# Altium schematic sheet S7CAS-PLEIADES-CARTE-MERE_P01_ALIM.SchDoc
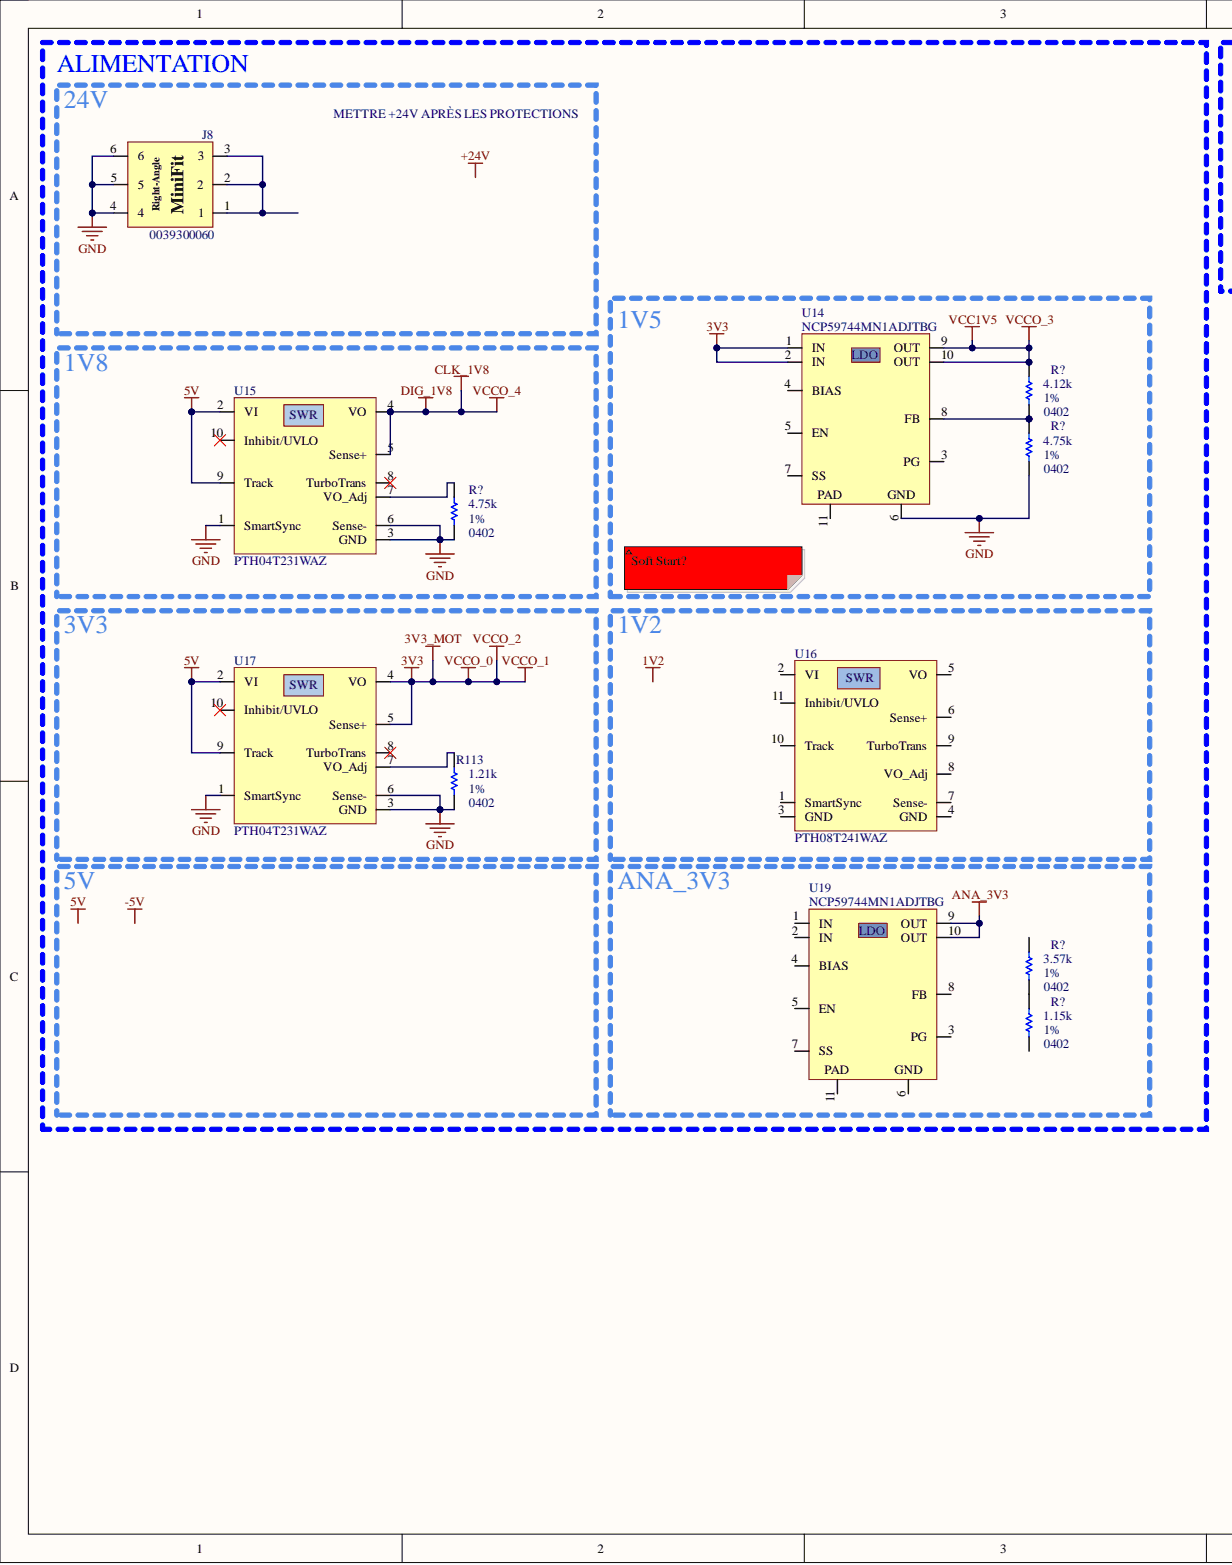
[diagram p0: S7CAS-PLEIADES-CARTE-MERE_P01_ALIM.SchDoc, left]
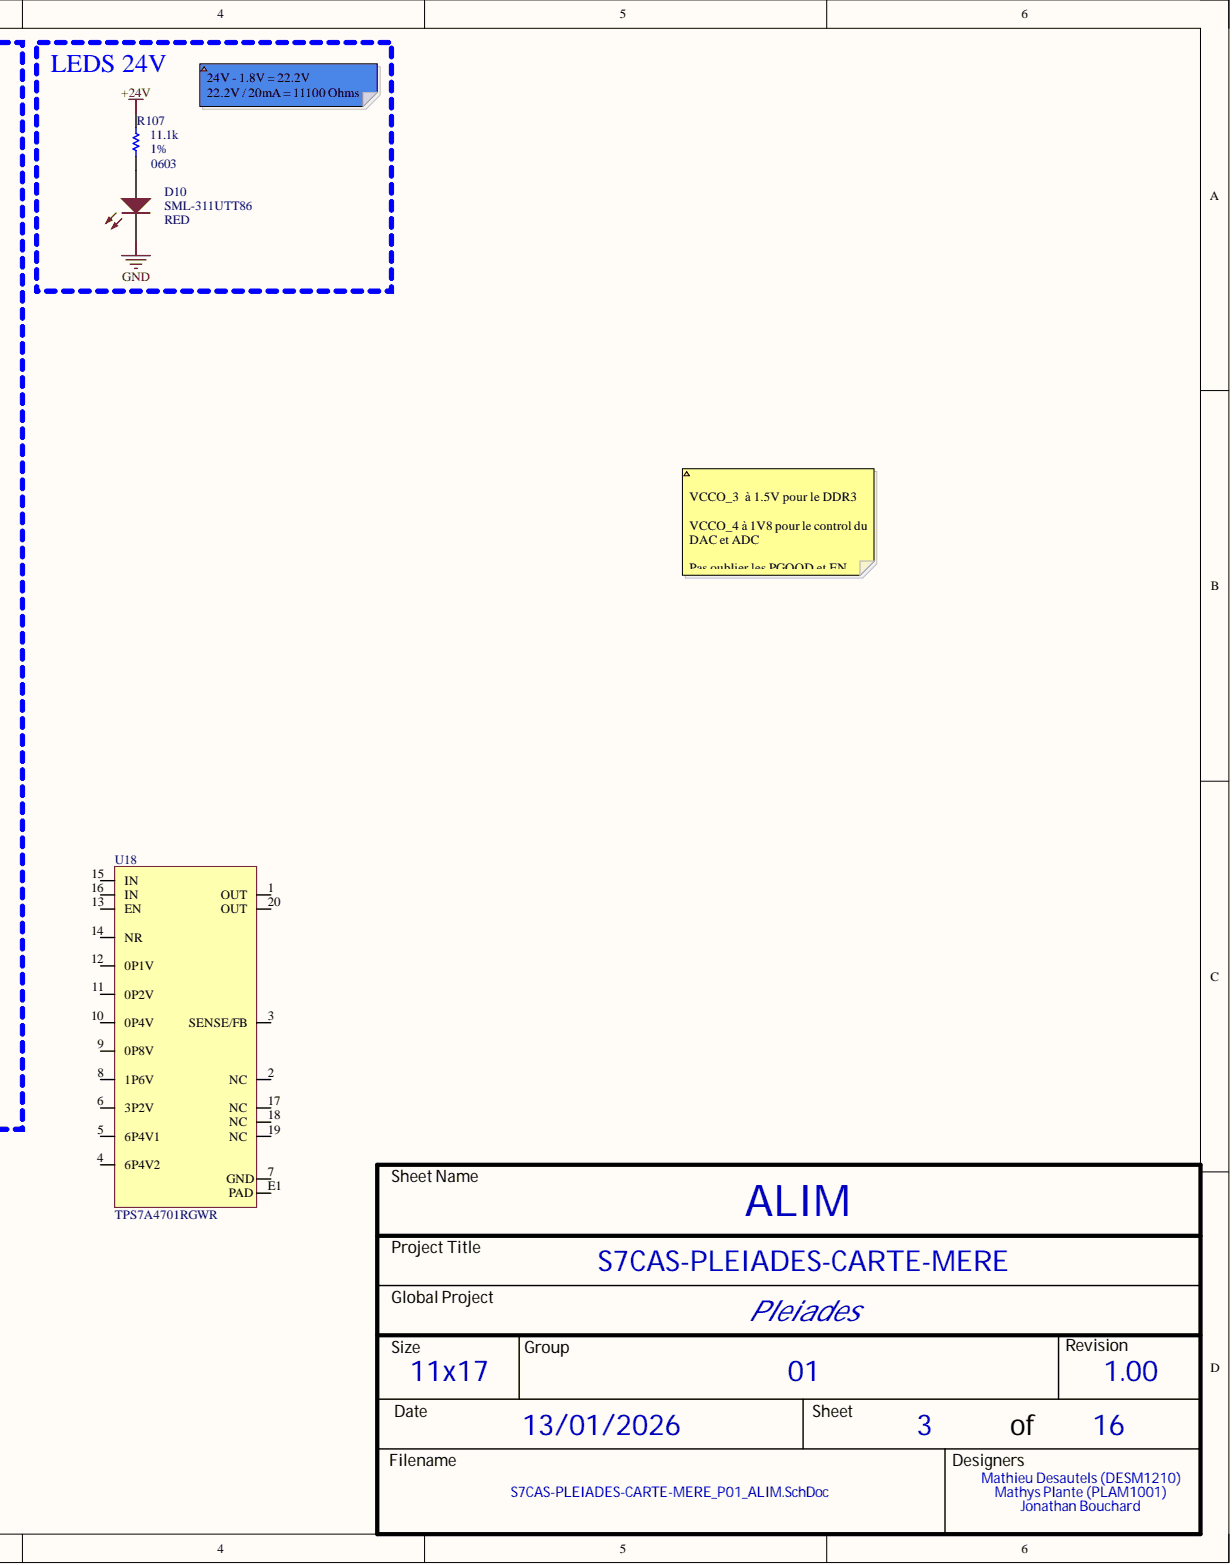
[diagram p1: S7CAS-PLEIADES-CARTE-MERE_P01_ALIM.SchDoc, right]

## Parts
D10  SML-311UTT86  value RED  Package: 0603  [LED_0603]
J8  0039300060  [CON_MINIFIT_0039300060]
R107  value 11.1k  Precision: 1%  [RES_0603]  (hidden comment: RES - 0603)
R113  value 1.21k  Package: 0402  Precision: 1%  [RES_0402]  (hidden comment: RES - 0402)
R?  value 3.57k  Package: 0402  Precision: 1%  [RES_0402]  (hidden comment: RES - 0402)
R?  value 1.15k  Package: 0402  Precision: 1%  [RES_0402]  (hidden comment: RES - 0402)
R?  value 4.12k  Package: 0402  Precision: 1%  [RES_0402]  (hidden comment: RES - 0402)
R?  value 4.75k  Package: 0402  Precision: 1%  [RES_0402]  (hidden comment: RES - 0402)
R?  value 4.75k  Package: 0402  Precision: 1%  [RES_0402]  (hidden comment: RES - 0402)
U14  NCP59744MN1ADJTBG  [REG_LDO_NCP59744MN1ADJTBG]
U15  PTH04T231WAZ  [REG_SWR_PTH04T231WAZ]
U16  PTH08T241WAZ  [REG_SWR_PTH08T241WAZ]
U17  PTH04T231WAZ  [REG_SWR_PTH04T231WAZ]
U18  TPS7A4701RGWR  [REG_TPS7A4701RGWR]
U19  NCP59744MN1ADJTBG  [REG_LDO_NCP59744MN1ADJTBG]

## Nets
3V3: U14.1(IN) U14.2(IN) U17.4(VO) U17.5(Sense+)
5V: U15.2(VI) U15.9(Track) U17.2(VI) U17.9(Track)
+24V: R107.2
ANA_3V3: U19.9(OUT) U19.10(OUT)
CLK_1V8: U15.4(VO) U15.5(Sense+)
GND: D10.K J8.4 J8.5 J8.6 R113.1 R?.1 U14.6 U15.1(SmartSync) U15.3(GND) U15.6(Sense-) U17.1(SmartSync) U17.3(GND) U17.6(Sense-)
VCC1V5: R?.2 U14.9(OUT) U14.10(OUT)
NetD10_A: D10.A R107.1
NetJ8_1: J8.1 J8.2 J8.3
NetR113_2: R113.2 U17.7(VO_Adj)
NetR?_1: R?.1 R?.2 U14.8(FB)
NetR?_2: R?.2 U15.7(VO_Adj)
1V2:
-5V:

Not connected: U14 3(PG) 4(BIAS) 5(EN) 7(SS) 11; U15 8(TurboTrans) 10(Inhibit/UVLO); U16 1(SmartSync) 2(VI) 3(GND) 4(GND) 5(VO) 6(Sense+) 7(Sense-) 8(VO_Adj) 9(TurboTrans) 10(Track) 11(Inhibit/UVLO); U17 8(TurboTrans) 10(Inhibit/UVLO); U18 1(OUT) 2(NC) 3(SENSE/FB) 4(6P4V2) 5(6P4V1) 6(3P2V) 7(GND) 8(1P6V) 9(0P8V) 10(0P4V) 11(0P2V) 12(0P1V) 13(EN) 14(NR) 15(IN) 16(IN) 17(NC) 18(NC) 19(NC) 20(OUT) E1(PAD); U19 1(IN) 2(IN) 3(PG) 4(BIAS) 5(EN) 6 7(SS) 8(FB) 11

## Library data of the parts
D10 = LED_SML-311UTT86: LED - 620nM RED 2mA - 0603; Mfg: ROHM Semiconductor; Power: 44mW; Voltage: 1.8V; Component Kind: Standard; Current: 2mA; HelpURL: http://media.digikey.com/pdf/Data%20Sheets/Rohm%20PDFs/SML-311%20Series.pdf; Library Name: s7cas_lib2023.intlib; Mfg Part Number: SML-311UTT86; Supplier 1: Digikey; Supplier Part Number 1: 511-1304-1-ND; Temperature: -30°C to 85°C; CreatedBy: Jonathan Bouchard
J8 = CON_MINIFIT_0039300060: CON - Mini-Fit Through Hole, Right Angle 6 position; Manufacturer #1: Molex; Cryo: UNKNOWN; Current Rating: 8A; GRAMS Lib Spec: 2.8; Polarized: Yes; Voltage Rating: 600V; Assembly Type: TH; Component Kind: Standard; HelpURL: https://www.molex.com/pdm_docs/sd/039300060_sd.pdf; Library Name: s7cas_lib2023.intlib; Magnetic Material: Unknown; Package: TH; Part Number #1: 0039300060; Supplier 1: Digi-Key; Supplier Part Number 1: WM21353-ND; Temperature: - 40°C to + 80°C; CreatedBy/Date: Joel Lemay 2018-06-21; VerifiedBy: Caroline Paulin - 10 sept 2018
R107 = RES - 0603: 11.1 kOhms ±0.1% 0.167W, 1/6W Chip Resistor 0603 (1608 Metric) Automotive AEC-Q200 Thin Film; Mfg Part Number: RNCF0603BTE11K1; Mfg: Stackpole Electronics Inc; Component Kind: Standard; Library Name: s7cas_lib2023.intlib; Package: 0603; CreatedBy: Jonathan Bouchard
R113 = RES - 0402: 1.21 kOhms ±1% 0.063W, 1/16W Chip Resistor 0402 (1005 Metric) Automotive AEC-Q200 Thick Film; Mfg Part Number: RMCF0402FT1K21; Mfg: Stackpole Electronics Inc; Voltage: 50V; Component Kind: Standard; Library Name: s7cas_lib2023.intlib; Power: 1/16W; CreatedBy: Jonathan Bouchard
R? = RES - 0402: 3.57 kOhms ±1% 0.063W, 1/16W Chip Resistor 0402 (1005 Metric) Moisture Resistant Thick Film; Mfg Part Number: RC0402FR-073K57L; Mfg: YAGEO; Voltage: 50V; Component Kind: Standard; Library Name: s7cas_lib2023.intlib; Power: 1/16W; CreatedBy: Jonathan Bouchard
R? = RES - 0402: 1.15 kOhms ±1% 0.1W, 1/10W Chip Resistor 0603 (1608 Metric) Automotive AEC-Q200 Thick Film; Mfg Part Number: ERJ-3EKF1151V; Mfg: Panasonic Electronic Components; Power: 1/16W; Voltage: 50V; Component Kind: Standard; Library Name: s7cas_lib2023.intlib; CreatedBy: Jonathan Bouchard
R? = RES - 0402: 4.12 kOhms ±0.1% 0.063W, 1/16W Chip Resistor 0402 (1005 Metric) Automotive AEC-Q200 Thin Film; Mfg Part Number: ERA-2AEB4121X; Mfg: Panasonic Electronic Components; Voltage: 50V; Component Kind: Standard; Library Name: s7cas_lib2023.intlib; Power: 1/16W; CreatedBy: Jonathan Bouchard
R? R? = RES - 0402: 4.75 kOhms ±0.1% 0.063W, 1/16W Chip Resistor 0402 (1005 Metric) Automotive AEC-Q200 Thin Film; Mfg Part Number: ERA-2AEB4751X; Mfg: Panasonic Electronic Components; Voltage: 50V; Component Kind: Standard; Library Name: s7cas_lib2023.intlib; Power: 1/16W; CreatedBy: Jonathan Bouchard
U14 U19 = REG_LDO_NCP59744MN1ADJTBG: REG - LINEAR POS ADJ 3A BIAS - 10-VFDFN; Manufacturer #1: ON Semiconductor; Cryo: UNKNOWN; GRAMS Lib Spec: 2.8; Polarized: Yes; Power Max: 10.8W; Value: 0.8V ~ 3.6V; Voltage Rating: (Vout+VDO) ~ 5.5V; Assembly Type: SMD; Component Kind: Standard; Current Rating: 3A; HelpURL: https://www.onsemi.com/pub/Collateral/NCP59744-D.PDF; Library Name: s7cas_lib2023.intlib; Magnetic Material: Unknown; Manufacturer #2: -; Note: -; Package: 10-VFDFN; Part Number #1: NCP59744MN1ADJTBG; Part Number #2: -; Supplier 1: Digi-Key; Supplier Part Number 1: NCP59744MN1ADJTBGOSCT-ND; Temperature: -40°C ~ 125°C (TJ); Tolerance: -; CreatedBy/Date: Raphael Bouchard / 2019-06-07; VerifiedBy: C.Paulin - 13/06/2019
U15 U17 = REG_SWR_PTH04T231WAZ: REG - MODULE PIP 6A 2.2V/5.5V - 10SMD; Manufacturer #1: Texas Instruments; Cryo: UNKNOWN; Current Rating: 6A (out); Polarized: Yes; Value: 0.69 - 3.6V (Out); Voltage rating: 2.2-5.5V (Input); Assembly Type: SMD; Component Kind: Standard; HelpURL: http://www.ti.com/lit/ds/symlink/pth04t231w.pdf; Library Name: s7cas_lib2023.intlib; Magnetic Material: UNKNOWN; Package: SMD; Part Number #1: PTH04T231WAZ; Supplier 1: Digi-Key; Supplier Part Number 1: 296-21792-ND; Temperature: -40°C ~ 85°C; CreatedBy/Date: Olivier Bernard / 6/5/2018; VerifiedBy: Caroline Paulin / 10 juillet 2018
U16 = REG_SWR_PTH08T241WAZ: REG - MODULE PIP 10A 14VIN - 11-SMD; Manufacturer #1: Texas Instruments; Cryo: UNKNOWN; Current Rating: 10A (out); Polarized: Yes; Voltage rating: 4.5-14V (Input), 0.69 - 5.5V (Out); Assembly Type: SMD; Component Kind: Standard; HelpURL: http://www.ti.com/lit/ds/symlink/pth08t241w.pdf; Library Name: s7cas_lib2023.intlib; Magnetic Material: UNKNOWN; Package: SMD; Part Number #1: PTH08T241WAZ; Supplier 1: Digi-Key; Supplier Part Number 1: 296-21339-ND; Temperature: -40°C ~ 85°C; CreatedBy/Date: Olivier Bernard / 6/5/2018; VerifiedBy: Caroline Paulin / 10 juillet 2018
U18 = REG_LDO_TPS7A4701RGWR: REG - LINEAR POS ADJ 1A - 20VQFN; Manufacturer #1: Texas Instruments; Cryo: UNKNOWN; GRAMS Lib Spec: 2.8; Polarized: Yes; Value: 1.4 à 34V; Voltage rating: 35V max; Assembly Type: SMD; Component Kind: Standard; Current Rating: 1A; HelpURL: http://www.ti.com/lit/ds/symlink/tps7a47.pdf; Library Name: s7cas_lib2023.intlib; Magnetic Material: Unknown; Manufacturer #2: TBD; Package: 20-VQFN Exposed Pad; Part Number #1: TPS7A4701RGWR; Part Number #2: TBD; Supplier 1: Digi-Key; Supplier Part Number 1: 296-37224-1-ND; Temperature: -40°C ~ 125°C; Tolerance: -; CreatedBy/Date: L-P Gauthier / 2018-05-29; VerifiedBy: Caroline Paulin - 28 sept 2018
